# Altium schematic sheet main.SchDoc
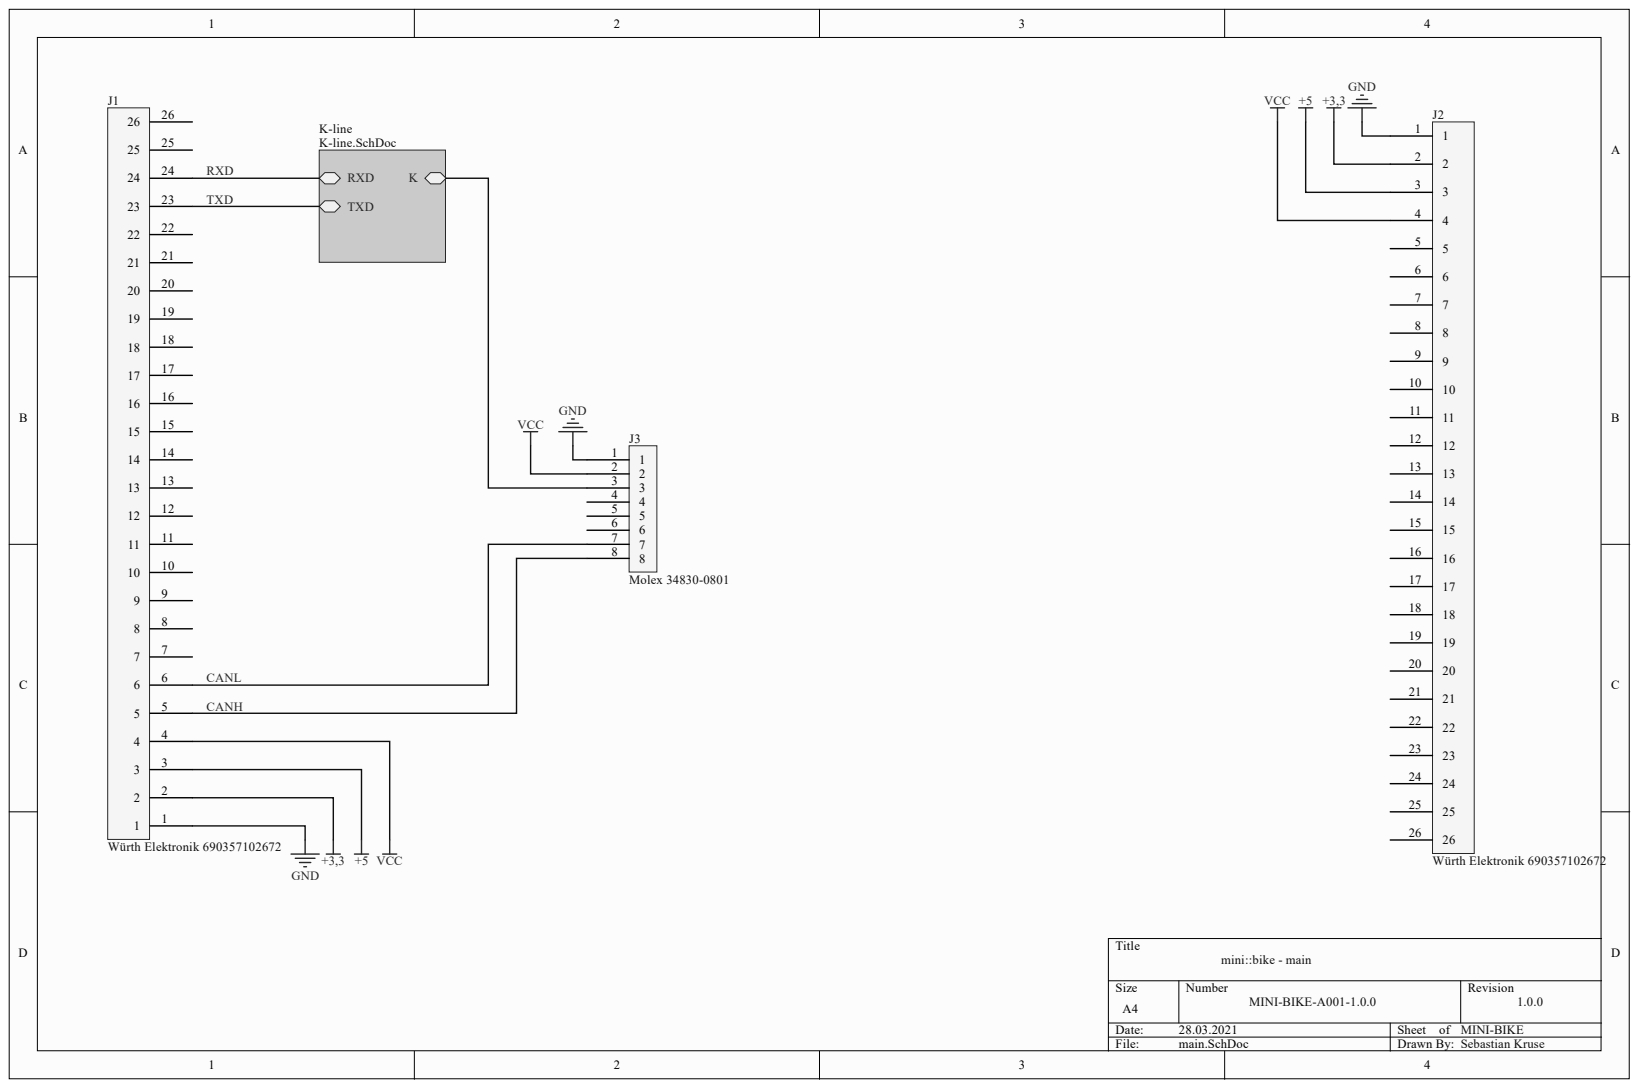
[diagram p0: main.SchDoc]

## Parts
J1  Würth Elektronik 690357102672  Manufacturer Part Number: 690357102672  [PCB-qkngfwumds4pa5a4mwtz-1]
J2  Würth Elektronik 690357102672  [PCB-qkngfwumds4pa5a4mwtz-1]
J3  Molex 34830-0801  Manufacturer Part Number: 34830-0801  [PCB-1mpzuevuzgsguukkiqai-1]

## Nets
+3,3: J1.2 J2.2
+5: J1.3 J2.3
GND: J1.1 J2.1 J3.1
VCC: J1.4 J2.4 J3.2
CANH: J1.5 J3.8
CANL: J1.6 J3.7
RXD: J1.24
TXD: J1.23
NetJ1_7: J1.7
NetJ1_8: J1.8
NetJ1_9: J1.9
NetJ1_10: J1.10
NetJ1_11: J1.11
NetJ1_12: J1.12
NetJ1_13: J1.13
NetJ1_14: J1.14
NetJ1_15: J1.15
NetJ1_16: J1.16
NetJ1_17: J1.17
NetJ1_18: J1.18
NetJ1_19: J1.19
NetJ1_20: J1.20
NetJ1_21: J1.21
NetJ1_22: J1.22
NetJ1_25: J1.25
NetJ1_26: J1.26
NetJ2_5: J2.5
NetJ2_6: J2.6
NetJ2_7: J2.7
NetJ2_8: J2.8
NetJ2_9: J2.9
NetJ2_10: J2.10
NetJ2_11: J2.11
NetJ2_12: J2.12
NetJ2_13: J2.13
NetJ2_14: J2.14
NetJ2_15: J2.15
NetJ2_16: J2.16
NetJ2_17: J2.17
NetJ2_18: J2.18
NetJ2_19: J2.19
NetJ2_20: J2.20
NetJ2_21: J2.21
NetJ2_22: J2.22
NetJ2_23: J2.23
NetJ2_24: J2.24
NetJ2_25: J2.25
NetJ2_26: J2.26
NetJ3_3: J3.3
NetJ3_4: J3.4
NetJ3_5: J3.5
NetJ3_6: J3.6

## Library data of the parts
J1 J2 = CMP-cccf062e80c51308-1: Male THT Straight Connector without Latch and with Polarization WR-MM, 26 pins; Contact Resistance: 10.0 m?; Current Rating: 1.50 A; Dielectric Withstanding Voltage: 500 V; Insulation Resistance: 1.00 ? (min); Operating Voltage: 100 V; Pin Pitch: 2.54 mm; Component Kind: Standard; Contact Material: Phosphor Bronze; Gender: Male; Library Name: FavoritesLibrary; Mounting Style: Through Hole; Number of Pins: 26; OctopartID: cccf062e80c51308; Octopart URL: https://octopart.com/690357102672-w%C3%BCrth+elektronik-65759596; Operating Temperature: -40.0 °C (min); Packaging: Tube
  J2: Manufacturer Part Number: 690357102672
J3 = CMP-e95d221892b7369a-5: MX150 2x4 Dual Row Right-Angle Header, 8 Circuits, Keying Option A, Black, Solder Tail; ELV Compliant: Compliant; Gender: Male; Glow Wire Compliant: Non-Compliant; Number of Circuits: 8; Color: Black; Component Kind: Standard; Contact Plating: Tin, Nickel; Halogen Free Status: Low Halogen; Library Name: FavoritesLibrary; Lifecycle Status: Active; Material: Copper Alloy; Mounting Style: Through Hole, Solder; OctopartID: e95d221892b7369a; Octopart URL: https://octopart.com/34830-0801-molex-38755980; Operating Temperature: -40.0 °C to 125 °C; Orientation: Right Angle; Packaging: Tray; RoHS: Compliant
